# Altium schematic sheet SSS Daughter Board Coils.SchDoc("SSS Daughter Board Coils")
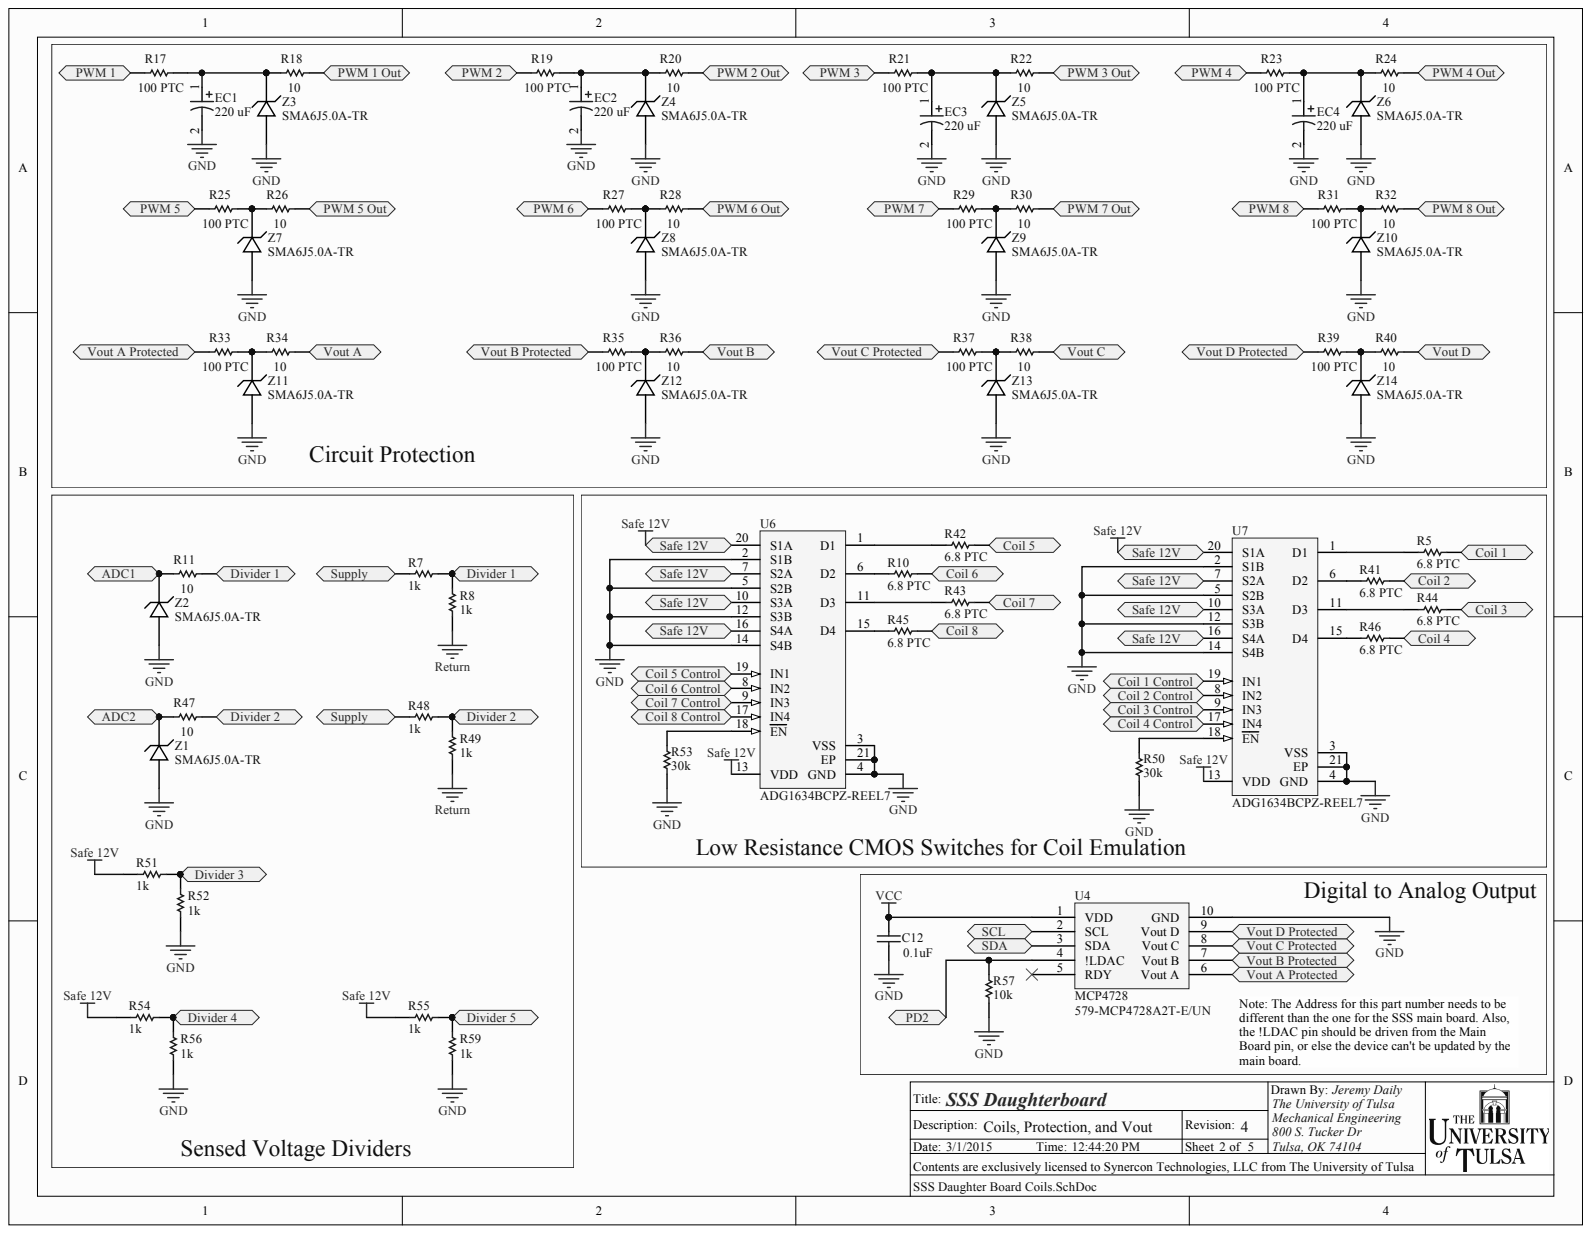
[diagram p0: SSS Daughter Board Coils.SchDoc]

## Parts
C12  0.1uF  [0603]
EC1  220 uF  [Elec. Cap - D]
EC2  220 uF  [Elec. Cap - D]
EC3  220 uF  [Elec. Cap - D]
EC4  220 uF  [Elec. Cap - D]
R5  6.8 PTC  [0805]
R7  1k  [0805]
R8  1k  [0805]
R10  6.8 PTC  [0805]
R11  10  [0805]
R17  100 PTC  [0603]
R18  10  [0805]
R19  100 PTC  [0603]
R20  10  [0805]
R21  100 PTC  [0603]
R22  10  [0805]
R23  100 PTC  [0603]
R24  10  [0805]
R25  100 PTC  [0603]
R26  10  [0805]
R27  100 PTC  [0603]
R28  10  [0805]
R29  100 PTC  [0603]
R30  10  [0805]
R31  100 PTC  [0603]
R32  10  [0805]
R33  100 PTC  [0603]
R34  10  [0805]
R35  100 PTC  [0603]
R36  10  [0805]
R37  100 PTC  [0603]
R38  10  [0805]
R39  100 PTC  [0603]
R40  10  [0805]
R41  6.8 PTC  [0805]
R42  6.8 PTC  [0805]
R43  6.8 PTC  [0805]
R44  6.8 PTC  [0805]
R45  6.8 PTC  [0805]
R46  6.8 PTC  [0805]
R47  10  [0805]
R48  1k  [0805]
R49  1k  [0805]
R50  30k  [0805]
R51  1k  [0805]
R52  1k  [0805]
R53  30k  [0805]
R54  1k  [0805]
R55  1k  [0805]
R56  1k  [0805]
R57  10k  [0805]
R59  1k  [0805]
U4  MCP4728  Supplier Part Number 1: 579-MCP4728A2T-E/UN  [MSOP-UN10_M]
U6  ADG1634BCPZ-REEL7  [ADI-CP-20-4_N]
U7  ADG1634BCPZ-REEL7  [ADI-CP-20-4_N]
Z1  SMA6J5.0A-TR  [SMA with A and K]
Z2  SMA6J5.0A-TR  [SMA with A and K]
Z3  SMA6J5.0A-TR  [SMA with A and K]
Z4  SMA6J5.0A-TR  [SMA with A and K]
Z5  SMA6J5.0A-TR  [SMA with A and K]
Z6  SMA6J5.0A-TR  [SMA with A and K]
Z7  SMA6J5.0A-TR  [SMA with A and K]
Z8  SMA6J5.0A-TR  [SMA with A and K]
Z9  SMA6J5.0A-TR  [SMA with A and K]
Z10  SMA6J5.0A-TR  [SMA with A and K]
Z11  SMA6J5.0A-TR  [SMA with A and K]
Z12  SMA6J5.0A-TR  [SMA with A and K]
Z13  SMA6J5.0A-TR  [SMA with A and K]
Z14  SMA6J5.0A-TR  [SMA with A and K]

## Ports
ADC1, ADC2, COIL 1, COIL 1 CONTROL, COIL 2, COIL 2 CONTROL, COIL 3, COIL 3 CONTROL, COIL 4, COIL 4 CONTROL, COIL 5, COIL 5 CONTROL, COIL 6, COIL 6 CONTROL, COIL 7, COIL 7 CONTROL, COIL 8, COIL 8 CONTROL, DIVIDER 1, DIVIDER 2, DIVIDER 3, DIVIDER 4, DIVIDER 5, PD2, PWM 1, PWM 1 OUT, PWM 2, PWM 2 OUT, PWM 3, PWM 3 OUT, PWM 4, PWM 4 OUT, PWM 5, PWM 5 OUT, PWM 6, PWM 6 OUT, PWM 7, PWM 7 OUT, PWM 8, PWM 8 OUT, SAFE 12V, SCL, SDA, SUPPLY, VOUT A, VOUT A PROTECTED, VOUT B, VOUT B PROTECTED, VOUT C, VOUT C PROTECTED, VOUT D, VOUT D PROTECTED

## Nets
GND: C12.2 EC1.2 EC2.2 EC3.2 EC4.2 R50.2 R52.1 R53.2 R56.1 R57.2 R59.1 U4.10(GND) U6.2(S1B) U6.3(VSS) U6.4(GND) U6.5(S2B) U6.12(S3B) U6.14(S4B) U6.21(EP) U7.2(S1B) U7.3(VSS) U7.4(GND) U7.5(S2B) U7.12(S3B) U7.14(S4B) U7.21(EP) Z1.1 Z2.1 Z3.1 Z4.1 Z5.1 Z6.1 Z7.1 Z8.1 Z9.1 Z10.1 Z11.1 Z12.1 Z13.1 Z14.1
RETURN: R8.1 R49.1
SAFE 12V: R51.2 R54.2 R55.2 U6.7(S2A) U6.10(S3A) U6.13(VDD) U6.16(S4A) U6.20(S1A) U7.7(S2A) U7.10(S3A) U7.13(VDD) U7.16(S4A) U7.20(S1A) ; port SAFE 12V
VCC: C12.1 U4.1(VDD)
ADC1: R11.2 Z2.2 ; port ADC1
ADC2: R47.2 Z1.2 ; port ADC2
PD2: R57.1 U4.4(!LDAC) ; port PD2
PWM 1: R17.2 ; port PWM 1
PWM 2: R19.2 ; port PWM 2
PWM 3: R21.2 ; port PWM 3
PWM 4: R23.2 ; port PWM 4
PWM 5: R25.2 ; port PWM 5
PWM 6: R27.2 ; port PWM 6
PWM 7: R29.2 ; port PWM 7
PWM 8: R31.2 ; port PWM 8
SCL: U4.2(SCL) ; port SCL
SDA: U4.3(SDA) ; port SDA
NetEC1_1: EC1.1 R17.1 R18.2 Z3.2
NetEC2_1: EC2.1 R19.1 R20.2 Z4.2
NetEC3_1: EC3.1 R21.1 R22.2 Z5.2
NetEC4_1: EC4.1 R23.1 R24.2 Z6.2
NetR5_1: R5.1 ; port COIL 1
NetR5_2: R5.2 U7.1(D1)
NetR7_1: R7.1 R8.2 R11.1 ; port DIVIDER 1
NetR7_2: R7.2 R48.2 ; port SUPPLY
NetR10_1: R10.1 ; port COIL 6
NetR10_2: R10.2 U6.6(D2)
NetR18_1: R18.1 ; port PWM 1 OUT
NetR20_1: R20.1 ; port PWM 2 OUT
NetR22_1: R22.1 ; port PWM 3 OUT
NetR24_1: R24.1 ; port PWM 4 OUT
NetR25_1: R25.1 R26.2 Z7.2
NetR26_1: R26.1 ; port PWM 5 OUT
NetR27_1: R27.1 R28.2 Z8.2
NetR28_1: R28.1 ; port PWM 6 OUT
NetR29_1: R29.1 R30.2 Z9.2
NetR30_1: R30.1 ; port PWM 7 OUT
NetR31_1: R31.1 R32.2 Z10.2
NetR32_1: R32.1 ; port PWM 8 OUT
NetR33_1: R33.1 R34.2 Z11.2
NetR33_2: R33.2 U4.6(Vout_A) ; port VOUT A PROTECTED
NetR34_1: R34.1 ; port VOUT A
NetR35_1: R35.1 R36.2 Z12.2
NetR35_2: R35.2 U4.7(Vout_B) ; port VOUT B PROTECTED
NetR36_1: R36.1 ; port VOUT B
NetR37_1: R37.1 R38.2 Z13.2
NetR37_2: R37.2 U4.8(Vout_C) ; port VOUT C PROTECTED
NetR38_1: R38.1 ; port VOUT C
NetR39_1: R39.1 R40.2 Z14.2
NetR39_2: R39.2 U4.9(Vout_D) ; port VOUT D PROTECTED
NetR40_1: R40.1 ; port VOUT D
NetR41_1: R41.1 ; port COIL 2
NetR41_2: R41.2 U7.6(D2)
NetR42_1: R42.1 ; port COIL 5
NetR42_2: R42.2 U6.1(D1)
NetR43_1: R43.1 ; port COIL 7
NetR43_2: R43.2 U6.11(D3)
NetR44_1: R44.1 ; port COIL 3
NetR44_2: R44.2 U7.11(D3)
NetR45_1: R45.1 ; port COIL 8
NetR45_2: R45.2 U6.15(D4)
NetR46_1: R46.1 ; port COIL 4
NetR46_2: R46.2 U7.15(D4)
NetR47_1: R47.1 R48.1 R49.2 ; port DIVIDER 2
NetR50_1: R50.1 U7.18(EN)
NetR51_1: R51.1 R52.2 ; port DIVIDER 3
NetR53_1: R53.1 U6.18(EN)
NetR54_1: R54.1 R56.2 ; port DIVIDER 4
NetR55_1: R55.1 R59.2 ; port DIVIDER 5
NetU6_8: U6.8(IN2) ; port COIL 6 CONTROL
NetU6_9: U6.9(IN3) ; port COIL 7 CONTROL
NetU6_17: U6.17(IN4) ; port COIL 8 CONTROL
NetU6_19: U6.19(IN1) ; port COIL 5 CONTROL
NetU7_8: U7.8(IN2) ; port COIL 2 CONTROL
NetU7_9: U7.9(IN3) ; port COIL 3 CONTROL
NetU7_17: U7.17(IN4) ; port COIL 4 CONTROL
NetU7_19: U7.19(IN1) ; port COIL 1 CONTROL

Not connected: U4 5(RDY)

## Library data of the parts
C12 = Cap: Component Kind: Standard; Signal Integrity: Cap; Simulation: CAP; Value: 0.1uF; Library Name: Daily_Integrated_Library.IntLib
EC1-EC4 = SMD Elec: Component Kind: Standard; Library Name: Capacitors.SchLib
R5 R7 R8 R10 R11 R17-R49 R51 R52 R54-R56 R59 = SMD Resistor: Component Kind: Standard; Library Name: Resistors.IntLib
R50 R53 R57 = Chip Resistor: Component Kind: Standard; Library Name: KongsLib.SchLib
U4 = MCP4728 DAC: Component Kind: Standard; Supplier 1: Mouser; Library Name: Drivers and Buffers.SchLib
U6 U7 = ADG1634BCPZ-REEL7: Manufacturer: Analog Devices; Component Kind: Standard; Library Name: Analog Devices Switches and Multiplexers Analog Switches.IntLib; PartNumber: ADG1634BCPZ-REEL7; Supplier 1: Digi-Key; Supplier Part Number 1: ADG1634BCPZ-REEL7TR-ND
Z1-Z14 = Zener: Component Kind: Standard; Library Name: SSS Schematic Library.SchLib
